# Altium schematic sheet VoltageSensorBoard.SchDoc(VoltageSensorBoard) (continued)

## Library data of the parts
B1 = CON_BARRIER_STRIP: Manufacturer: Molex; Wire Gauge (Min): 14AWG; Wire Gauge (Max): 22AWG; Voltage Rating: 300V; Pitch: 0.325inch; Number of Wire Entries: 2; Current Rating: 20A; Termination: Solder; Supplier Part Number 1: WM13920-ND; Supplier 1: Digi-Key; Stock 1: 2658; RoHS: Yes; Pricing 1: 1=2.41000, 10=1.82000, 25=1.68720, 50=1.51700, 100=1.48000, 250=1.29500, 500=1.18400, 1000=1.07300, 5000=0.94350 (USD); Packaging: Bulk; Package Quantity: 468; Orientation: Straight; Number of Rows: 1; Number of Contacts: 2; Number of Circuits: 2; Mount: Through Hole; Min Operating Temperature: -40°C; Max Supply Voltage: 300V; Max Operating Temperature: 130°C; Library Name: SensorCard.SchLib; Length: 18.5mm; Insulation Material: Thermoplastic; Housing Material: Thermoplastic; Housing Color: Black; Depth: 12.7mm; Contact Plating: Zinc; Contact Material: Steel; ComponentLink1URL: https://datasheet.ciiva.com/6772/387007501-sd-6772068.pdf?src-supplier=Digi-Key; ComponentLink1Description: https://datasheet.ciiva.com/6772/387007501-sd-6772068.pdf?src-supplier=Digi-Key; Component Kind: Standard; Color: Black
BNC1 = BNC: Manufacturer Part Number: 5227699-1; Manufacturer: TE Connectivity; Voltage Rating: 500V; Sealable: No (Without); Polarity: Standard; PCB Mount Retention: Without; PCB Mounting Orientation: Vertical; Number of Positions: 1; Max Frequency: 4GHz; Insulation Resistance: 5GR; Impedance: 50R; Gender: Female; Body Plating: Nickel; Body Material: Zinc; Termination: Solder; Supplier Part Number 1: A33798-ND; Supplier 1: Digi-Key; Stock 1: 1551; RoHS: Yes; REACH SVHC: Unknown; Radiation Hardening: No; Pricing 1: 1=3.67000, 10=3.52000, 30=3.22700, 60=3.08017, 120=2.93358, 270=2.56685, 510=2.49353, 1020=2.12683, 2520=1.98015 (USD); Packaging: Rail/Tube; Package Quantity: 30; Orientation: Straight; Mount: Through Hole; Min Operating Temperature: -55°C; Max Operating Temperature: 85°C; Library Name: SensorCard.SchLib; Length: 17.45mm; Lead Free: Lead Free; Frequency: 4GHz; Fastening Type: Bayonet; ELV: Compliant; Digikey Part no.: A33798-ND; Depth: 17.45mm; Contact Plating: Silver; Contact Material: Bronze; Connector Type: BNC; ComponentLink5URL: https://datasheet.ciiva.com/3131/eng-cs-1307191-bnc-connectors-0307-3131320.pdf?src-supplier=Avnet; ComponentLink5Description: https://datasheet.ciiva.com/3131/eng-cs-1307191-bnc-connectors-0307-3131320.pdf?src-supplier=Avnet; ComponentLink4URL: https://datasheet.ciiva.com/14565/70042557-14565526.pdf?src-supplier=Allied+Electronics; ComponentLink4Description: https://datasheet.ciiva.com/14565/70042557-14565526.pdf?src-supplier=Allied+Electronics; ComponentLink3URL: https://datasheet.ciiva.com/8044/1649653-8044086.pdf?src-supplier=Element14; ComponentLink3Description: https://datasheet.ciiva.com/8044/1649653-8044086.pdf?src-supplier=Element14; ComponentLink2URL: https://datasheet.ciiva.com/642/eng-cd-5227699-a1-642820.pdf?src-supplier=Digi-Key; ComponentLink2Description: https://datasheet.ciiva.com/642/eng-cd-5227699-a1-642820.pdf?src-supplier=Digi-Key; ComponentLink1URL: https://datasheet.ciiva.com/30491/0900766b8121e450-30491606.pdf?src-supplier=RSComponents; ComponentLink1Description: https://datasheet.ciiva.com/30491/0900766b8121e450-30491606.pdf?src-supplier=RSComponents; Component Kind: Standard
C1-C4 C9 = CMP-2006-03069-1: Manufacturer: Kemet; Voltage Rating (DC): 25V; Tolerance: 80%; Dissipation Factor: 7%; Dielectric Material: Ceramic; Dielectric: Y5V; Width: 0.031inch; Voltage Rating: 25V; Voltage: 25V; Thickness: 0.034inch; Termination: SMD/SMT; Supplier Part Number 1: 399-1100-1-ND; Supplier 1: Digi-Key; Stock 1: 1688362; RoHS: Yes; REACH SVHC: No SVHC; Radiation Hardening: No; Pricing 1: 1=0.10000, 10=0.03500, 100=0.01560, 500=0.01114, 1000=0.00875 (USD); Part Number: C0603C104Z3VACTU; Packaging: Tape and Reel; Package Quantity: 1; Number of Pins: 2; Mount: Surface Mount; Min Operating Temperature: -30°C; Max Operating Temperature: 85°C; Manufacturer Part Number: C0603C104Z3VACTU; Library Name: Altium Content Vault; Length: 1.6mm; Lead Pitch: 0.7mm; Lead Free: Lead Free; Height: 0.8mm; Depth: 0.8mm; ComponentLink4URL: https://datasheet.ciiva.com/14589/70095383-14589007.pdf?src-supplier=Allied+Electronics; ComponentLink4Description: https://datasheet.ciiva.com/14589/70095383-14589007.pdf?src-supplier=Allied+Electronics; ComponentLink3URL: https://datasheet.ciiva.com/46443/1828802-46443709.pdf?src-supplier=Element14; ComponentLink3Description: https://datasheet.ciiva.com/46443/1828802-46443709.pdf?src-supplier=Element14; ComponentLink2URL: https://datasheet.ciiva.com/46443/1828802-46443708.pdf?src-supplier=Element14; ComponentLink2Description: https://datasheet.ciiva.com/46443/1828802-46443708.pdf?src-supplier=Element14; ComponentLink1URL: https://datasheet.ciiva.com/7823/1470417-7823994.pdf?src-supplier=Element14; ComponentLink1Description: https://datasheet.ciiva.com/7823/1470417-7823994.pdf?src-supplier=Element14; Component Kind: Standard; Case Code (Metric): 1608; Case Code (Imperial): 0603; Case/Package: 0603
C5 = CMP-1662-00022-1: Chip Capacitor, 1 nF, +/- 5%, 50 V, -55 to 125 degC, 0603 (1608 Metric), RoHS, Tape and Reel; Manufacturer: Murata; Voltage Rating (DC): 50 V; Voltage: 50V; Temperature Coefficient: 30ppm/°C; Resistance: 100000000000 Ohm; Material: Ceramic; Insulation Resistance: 10GR; Width: 0.8 mm; Voltage Rating: 50 V; Tolerance: 5%; Thickness: 0.035inch; Termination: SMD/SMT; Supplier Part Number 1: 490-1451-1-ND; Supplier 1: Digi-Key; Stock 1: 1837786; RoHSCompliant: True; RoHS: Yes; REACH SVHC: No SVHC; Radiation Hardening: No; Pricing 1: 1=0.10000, 10=0.07000, 100=0.03300, 500=0.02314, 1000=0.01916 (USD); Pins: 2; Packaging: Tape and Reel; PackageReference: 0603; Package Quantity: 4000; PackageDescription: 2-Pin Surface Mount Device, Body 1.6 x 0.8 mm; Number of Pins: 2; Mount: Surface Mount; Min Operating Temperature: -55degC; Max Operating Temperature: 125degC; Manufacturer Part Number: GRM1885C1H102JA01D; Library Name: Altium Content Vault; Length: 1.6 mm; Lead Free: Lead Free; Height: 0.8 mm; Dielectric Material: Ceramic; Dielectric: C0G; Depth: 0.8mm; DatasheetVersion: Mar-16; ComponentLink12URL: https://datasheet.ciiva.com/7828/4360-7828895.pdf?src-supplier=Element14; ComponentLink12Description: https://datasheet.ciiva.com/7828/4360-7828895.pdf?src-supplier=Element14; ComponentLink11URL: https://datasheet.ciiva.com/7828/1784402-7828894.pdf?src-supplier=Element14; ComponentLink11Description: https://datasheet.ciiva.com/7828/1784402-7828894.pdf?src-supplier=Element14; ComponentLink10URL: https://datasheet.ciiva.com/7828/1784402-7828893.pdf?src-supplier=Element14; ComponentLink10Description: https://datasheet.ciiva.com/7828/1784402-7828893.pdf?src-supplier=Element14; ComponentLink9URL: https://datasheet.ciiva.com/131/partnumbering-e-01-131965.pdf?src-supplier=Digi-Key; ComponentLink9Description: https://datasheet.ciiva.com/131/partnumbering-e-01-131965.pdf?src-supplier=Digi-Key; ComponentLink8URL: https://datasheet.ciiva.com/6072/4360-6072460.pdf?src-supplier=Farnell; ComponentLink8Description: https://datasheet.ciiva.com/6072/4360-6072460.pdf?src-supplier=Farnell; ComponentLink7URL: https://datasheet.ciiva.com/131/partnumbering-e-01-131964.pdf?src-supplier=Digi-Key; ComponentLink7Description: https://datasheet.ciiva.com/131/partnumbering-e-01-131964.pdf?src-supplier=Digi-Key; ComponentLink6URL: https://datasheet.ciiva.com/131/partnumbering-e-01-131963.pdf?src-supplier=Digi-Key; ComponentLink6Description: https://datasheet.ciiva.com/131/partnumbering-e-01-131963.pdf?src-supplier=Digi-Key; ComponentLink5URL: https://datasheet.ciiva.com/5421/product-5421450.pdf?src-supplier=Mouser; ComponentLink5Description: https://datasheet.ciiva.com/5421/product-5421450.pdf?src-supplier=Mouser; ComponentLink4URL: https://datasheet.ciiva.com/29149/450301-da-01-en-vs-kondensator-0603-29149020.pdf?src-supplier=Conrad; ComponentLink4Description: https://datasheet.ciiva.com/29149/450301-da-01-en-vs-kondensator-0603-29149020.pdf?src-supplier=Conrad; ComponentLink3URL: https://datasheet.ciiva.com/18184/getdatasheetpartid-153109-18184812.pdf?src-supplier=Verical; ComponentLink3Description: https://datasheet.ciiva.com/18184/getdatasheetpartid-153109-18184812.pdf?src-supplier=Verical; ComponentLink2URL: http://search.murata.co.jp/Ceramy/image/img/A01X/G101/ENG/GRM1885C1H102JA01-01.pdf; ComponentLink2Description: Datasheet; ComponentLink1URL: http://www.murata.com/; ComponentLink1Description: Manufacturer URL; Component Kind: Standard; Case Code (Metric): 1608; Case Code (Imperial): 0603; Case/Package: 0603
C6-C8 C10 = Cap: Capacitor; Manufacturer Part Number: CL21A106KAFN3NE; Manufacturer: Samsung; Voltage Rating: 25V; Tolerance: 10%; Width: 0.049inch; Thickness: 0.053inch; Supplier Part Number 1: 1276-2890-1-ND; Supplier 1: Digi-Key; Stock 1: 51388; RoHS: Yes; Pricing 1: 1=0.28000, 10=0.19300, 100=0.09770, 500=0.06684, 1000=0.05912 (USD); Packaging: Cut Tape; Package Quantity: 1; Mount: Surface Mount; Min Operating Temperature: -55°C; Max Operating Temperature: 85°C; Library Name: SensorCard.SchLib; Length: 0.079inch; Lead Free: Lead Free; ComponentLink1URL: https://datasheet.ciiva.com/25720/cl21a106kafn3ne-25720275.pdf?src-supplier=Digi-Key; ComponentLink1Description: https://datasheet.ciiva.com/25720/cl21a106kafn3ne-25720275.pdf?src-supplier=Digi-Key; Component Kind: Standard; Case Code (Metric): 2012; Case Code (Imperial): 0805; Case/Package: 0805
DS1 = CMP-2000-06622-1: LED Green, 75 mW, 80 mA, -55 to 85 degC, 2-Pin SMD, RoHS, Tape and Reel; Manufacturer: Lite-On; Viewing Angle: 130°; Test Current: 5mA; Reverse Voltage: 5V; Power Rating: 75mW; Number of LEDs: 1; Luminous Intensity: 28mcd; Lens Type: Clear; Lens Style: Diffused; Lens Color: Colorless; Forward Voltage: 2.3V; Forward Current: 30mA; Dominant Wavelength: 576.5nm; Width: 0.8mm; Supplier Part Number 1: 160-1828-1-ND; Supplier 1: Digi-Key; Stock 1: 98599; RoHS: Yes; Radiation Hardening: No; Pricing 1: 1=0.41000, 10=0.29600, 25=0.22680, 100=0.14800, 250=0.10852, 500=0.09210, 1000=0.07565, 2500=0.06578 (USD); Power Dissipation: 75mW; Packaging: Tape and Reel; Package Reference: C193KGKT-5A; Package Quantity: 3000; Package Description: 2-Pin Surface Mount Device, Body 1.6 x 0.8 mm; Number of Pins: 2; Number of Elements: 1; Mounting Technology: SurfaceMount; Mount: Surface Mount; Min Operating Temperature: -55°C; Max Operating Temperature: 85°C; Manufacturer URL: http://www.us.liteon.com/; Manufacturer Part Number: LTST-C193KGKT-5A; Library Name: Altium Content Vault; Lens Transparency: Clear; Length: 1.6mm; Lead Free: Lead Free; Intensity: 28mcd; Illumination Color: Green; Height: 0.35mm; Forward (Drive) Current: 5mA; Dimension: 1.6x0.8x0.35mm; Depth: 0.8mm; Datasheet Version: 03/2007; Datasheet URL: http://optoelectronics.liteon.com/upload/download/DS22-2007-0094/S_110_LTST-C193KGKT-5A.pdf; ComponentLink6URL: https://datasheet.ciiva.com/2110/s-110-ltst-c193kgkt-5a-2110268.pdf?src-supplier=Digi-Key; ComponentLink6Description: https://datasheet.ciiva.com/2110/s-110-ltst-c193kgkt-5a-2110268.pdf?src-supplier=Digi-Key; ComponentLink5URL: https://datasheet.ciiva.com/2110/s-110-ltst-c193kgkt-5a-2110269.pdf?src-supplier=Digi-Key; ComponentLink5Description: https://datasheet.ciiva.com/2110/s-110-ltst-c193kgkt-5a-2110269.pdf?src-supplier=Digi-Key; ComponentLink4URL: https://datasheet.ciiva.com/2110/s-110-ltst-c193kgkt-5a-2110267.pdf?src-supplier=Digi-Key; ComponentLink4Description: https://datasheet.ciiva.com/2110/s-110-ltst-c193kgkt-5a-2110267.pdf?src-supplier=Digi-Key; ComponentLink3URL: https://datasheet.ciiva.com/16444/ltst-c193kgkt-5a-16444759.pdf?src-supplier=Future+Electronics; ComponentLink3Description: https://datasheet.ciiva.com/16444/ltst-c193kgkt-5a-16444759.pdf?src-supplier=Future+Electronics; ComponentLink2URL: https://datasheet.ciiva.com/11773/0900766b80dc0550-11773612.pdf?src-supplier=RSComponents; ComponentLink2Description: https://datasheet.ciiva.com/11773/0900766b80dc0550-11773612.pdf?src-supplier=RSComponents; ComponentLink1URL: https://datasheet.ciiva.com/12400/ltst-c193-series-201756-12400795.pdf?src-supplier=Mouser; ComponentLink1Description: https://datasheet.ciiva.com/12400/ltst-c193-series-201756-12400795.pdf?src-supplier=Mouser; Component Kind: Standard; Color: Green; Case Code (Metric): 1608; Case Code (Imperial): 0603; Case/Package: 0603
DS2 = CMP-2000-06624-1: LED Uni-Color Blue, 76 mW, 20 mA, -20 to 80 degC, 2-Pin SMD, RoHS, Tape and Reel; Manufacturer: Lite-On; Wavelength/Color Temperature: 465nmto475nm; Viewing Angle: 130deg; Test Current: 5mA; Reverse Voltage: 5V; Power Dissipation: 76mW; Number of LEDs: 1; Luminous Intensity: 15mcd; Lens Transparency: Clear; Lens Style: Diffused; Lens Color: Colorless; LED Size: 1.6mmx0.8mmx0.35mm; Forward Voltage: 2.8V; Dominant Wavelength: 475nm; Width: 0.8mm; Supplier Part Number 1: 160-1827-1-ND; Supplier 1: Digi-Key; Stock 1: 234360; RoHS: Details; Radiation Hardening: No; Product Category: StandardLEDs-SMD; Pricing 1: 1=0.47000, 10=0.33600, 25=0.25720, 100=0.16780, 250=0.12304, 500=0.10442, 1000=0.08577, 2500=0.07458 (USD); Packaging: Reel; Package Reference: C193TBKT; Package Quantity: 1; Package Description: 2-Pin Surface Mount Device, Body 0.8 x 1.6 mm; Package / Case: 0603; Number of Pins: 2; Number of Elements: 1; Mounting Technology: SurfaceMount; Mount: Surface Mount; Min Operating Temperature: -20°C; Minimum Operating Temperature: -20C; Max Operating Temperature: 80°C; Maximum Operating Temperature: +80C; Manufacturer URL: http://www.us.liteon.com/; Manufacturer Part Number: LTST-C193TBKT-5A; Library Name: Altium Content Vault; Length: 1.6mm; Lead Free: Lead Free; Illumination Color: Blue; Height: 0.35mm; Forward Current: 5mA; Factory Pack Quantity: 3000; Depth: 0.8mm; Datasheet Version: 08/2011; Datasheet URL: https://datasheet.ciiva.com/15201/getdatasheetpartid-293056-15201395.pdf; ComponentLink7URL: https://datasheet.ciiva.com/2111/p-100-ltst-c193tbkt-5a-2111221.pdf?src-supplier=Digi-Key; ComponentLink7Description: https://datasheet.ciiva.com/2111/p-100-ltst-c193tbkt-5a-2111221.pdf?src-supplier=Digi-Key; ComponentLink6URL: https://datasheet.ciiva.com/2111/p-100-ltst-c193tbkt-5a-2111220.pdf?src-supplier=Digi-Key; ComponentLink6Description: https://datasheet.ciiva.com/2111/p-100-ltst-c193tbkt-5a-2111220.pdf?src-supplier=Digi-Key; ComponentLink5URL: https://datasheet.ciiva.com/16210/ltst-c193tbkt-5a-16210824.pdf?src-supplier=Future+Electronics; ComponentLink5Description: https://datasheet.ciiva.com/16210/ltst-c193tbkt-5a-16210824.pdf?src-supplier=Future+Electronics; ComponentLink4URL: https://datasheet.ciiva.com/11773/0900766b80dc0554-11773880.pdf?src-supplier=RSComponents; ComponentLink4Description: https://datasheet.ciiva.com/11773/0900766b80dc0554-11773880.pdf?src-supplier=RSComponents; ComponentLink3URL: https://datasheet.ciiva.com/15201/getdatasheetpartid-293056-15201395.pdf?src-supplier=Verical; ComponentLink3Description: https://datasheet.ciiva.com/15201/getdatasheetpartid-293056-15201395.pdf?src-supplier=Verical; ComponentLink2URL: https://datasheet.ciiva.com/2111/ltst-c193tbkt-5a-2111219.pdf?src-supplier=Digi-Key; ComponentLink2Description: https://datasheet.ciiva.com/2111/ltst-c193tbkt-5a-2111219.pdf?src-supplier=Digi-Key; ComponentLink1URL: https://datasheet.ciiva.com/4688/lite-on-ltst-c193tbkt-5a-187386-4688832.pdf?src-supplier=Mouser; ComponentLink1Description: https://datasheet.ciiva.com/4688/lite-on-ltst-c193tbkt-5a-187386-4688832.pdf?src-supplier=Mouser; Component Kind: Standard; Color: Blue; Case Code (Metric): 1608; Case Code (Imperial): 0603; Case/Package: 0603; Brand: Lite-On
IC1 = LV25-P: LA 25-P Voltage Sensor; Library Name: SensorCard.SchLib; Digikey Part no.: 398-1010-ND; Component Kind: Standard
L1-L3 = CMP-0220-00193-1: SMD EMI Suppression Ferrite Bead WE-CBF, Z = 30 Ohm; Manufacturer: Wurth Electronics; Tolerance: 25%; Test Frequency: 100MHz; Resistance: 40mR; Number of Lines: 1; Max DC Current: 3A; Inductance: 5.6H; Impedance: 30 Ohm; Current Rating: 1A; Width: 0.8mm; Weight: 0.000141oz; Termination: SMD/SMT; Supplier Part Number 1: 732-1591-1-ND; Supplier 1: Digi-Key; Stock 1: 70099; RoHS: Yes; REACH SVHC: Unknown; Pricing 1: 1=0.17000, 50=0.16800, 100=0.15500, 500=0.14800, 1000=0.14100, 2000=0.13400 (USD); Packaging: Tape and Reel; PackageVersion: 2009; PackageReference: SMD-0603; Package Quantity: 1; PackageDescription: Chip Inductor, 2-Leads, Body 1.6 x 0.8 mm; Mount: Surface Mount; Min Operating Temperature: -55°C; Max Operating Temperature: 125°C; Max Current Rating: 3A; Material: Ferrite; Manufacturer Part Number: 742792609; Library Name: Altium Content Vault; Length: 1.6mm; Height: 0.8mm; Depth: 0.8mm; DC Resistance (DCR): 40mR; DatasheetVersion: Dec-2005; Core Material: Ferrite; ComponentLink11URL: https://datasheet.ciiva.com/1013/742792609-1013544.pdf?src-supplier=Digi-Key; ComponentLink11Description: https://datasheet.ciiva.com/1013/742792609-1013544.pdf?src-supplier=Digi-Key; ComponentLink10URL: https://datasheet.ciiva.com/1013/742792609-1013543.pdf?src-supplier=Digi-Key; ComponentLink10Description: https://datasheet.ciiva.com/1013/742792609-1013543.pdf?src-supplier=Digi-Key; ComponentLink9URL: https://datasheet.ciiva.com/1466/1696069-1466420.pdf?src-supplier=Element14; ComponentLink9Description: https://datasheet.ciiva.com/1466/1696069-1466420.pdf?src-supplier=Element14; ComponentLink8URL: https://datasheet.ciiva.com/23762/742792609-23762991.pdf?src-supplier=Newark; ComponentLink8Description: https://datasheet.ciiva.com/23762/742792609-23762991.pdf?src-supplier=Newark; ComponentLink7URL: https://datasheet.ciiva.com/11799/0900766b80d2ddc1-11799675.pdf?src-supplier=RSComponents; ComponentLink7Description: https://datasheet.ciiva.com/11799/0900766b80d2ddc1-11799675.pdf?src-supplier=RSComponents; ComponentLink6URL: https://datasheet.ciiva.com/14634/0900766b80d2ddc1-14634954.pdf?src-supplier=Allied+Electronics; ComponentLink6Description: https://datasheet.ciiva.com/14634/0900766b80d2ddc1-14634954.pdf?src-supplier=Allied+Electronics; ComponentLink5URL: https://datasheet.ciiva.com/4940/742792609-79267-4940428.pdf?src-supplier=Mouser; ComponentLink5Description: https://datasheet.ciiva.com/4940/742792609-79267-4940428.pdf?src-supplier=Mouser; ComponentLink4URL: https://datasheet.ciiva.com/1013/742792609-1013542.pdf?src-supplier=Digi-Key; ComponentLink4Description: https://datasheet.ciiva.com/1013/742792609-1013542.pdf?src-supplier=Digi-Key; ComponentLink3URL: https://datasheet.ciiva.com/22711/1696069-22711408.pdf?src-supplier=Element14; ComponentLink3Description: https://datasheet.ciiva.com/22711/1696069-22711408.pdf?src-supplier=Element14; ComponentLink2URL: http://www.we-online.de/katalog/media/pdf/742792609.pdf; ComponentLink2Description: Datasheet; ComponentLink1URL: http://www.we-online.com; ComponentLink1Description: Manufacturer Link; Component Kind: Standard; Case Code (Metric): 1608; Case Code (Imperial): 0603; Case/Package: SMD/SMT
MH1 MH2 = MOUNT_HOLE: MOUNTING HOLE; Library Name: SensorCard.SchLib; Component Kind: Standard
P1 = HDR1x3: Manufacturer Part Number: 282834-3; Manufacturer: TE Connectivity; Wire Gauge (Min): 30AWG; Voltage Rating: 150V; Thread Size: M1.6; Tail Length: 3.4mm; Side Stackable: Yes (With); Screw Flange: No (Without); Plating: Brass; Pitch: 2.54mm; Number of Positions Per Level: 3; Number of Levels: 1; Max Current Rating: 10A; Connector Type: Receptacle; Approvals: UL; Wire Gauge (Max): 30AWG; Wire/Cable Gauge: 30AWG; Width: 10mm; Voltage: 150V; Termination: Solder; Supplier Part Number 1: A98334-ND; Supplier 1: Digi-Key; Stock 1: 4635; Screwless Terminal Block: Yes (With); RoHS: Yes; REACH SVHC: Unknown; Radiation Hardening: No; Pricing 1: 1=2.01000, 10=1.52300, 25=1.41160, 50=1.26920, 100=1.23820, 250=1.08340, 500=0.99052, 1000=0.89767, 5000=0.78933 (USD); Packaging: Bulk; Package Quantity: 200; Orientation: Straight; Number of Rows: 1; Number of Positions: 3; Number of Poles: 3; Number of Contacts: 3; Number of Circuits: 3; Mount: Through Hole; Min Operating Temperature: -40°C; Max Voltage Rating (AC): 150V; Max Supply Voltage (AC): 150V; Max Operating Temperature: 105°C; Library Name: SensorCard.SchLib; Length: 8.08mm; Lead Free: Lead Free; Interlock: No (Without); Housing Material: Polyamide; Housing Color: Green; Flammability Rating: UL94 V-0; ELV: Compliant; Depth: 6.5mm; Current Rating: 10A; Current: 10A; Contact Plating: Tin; Contact Material: Brass; ComponentLink8URL: https://datasheet.ciiva.com/7978/583107-7978559.pdf?src-supplier=Element14; ComponentLink8Description: https://datasheet.ciiva.com/7978/583107-7978559.pdf?src-supplier=Element14; ComponentLink7URL: https://datasheet.ciiva.com/16469/eng-cs-1308389-eurostyle-terminal-blocks-0607-16469502.pdf?src-supplier=Avnet+Asia; ComponentLink7Description: https://datasheet.ciiva.com/16469/eng-cs-1308389-eurostyle-terminal-blocks-0607-16469502.pdf?src-supplier=Avnet+Asia; ComponentLink6URL: https://datasheet.ciiva.com/3141/eng-cs-1308389-eurostyle-terminal-blocks-0607-3141116.pdf?src-supplier=Avnet; ComponentLink6Description: https://datasheet.ciiva.com/3141/eng-cs-1308389-eurostyle-terminal-blocks-0607-3141116.pdf?src-supplier=Avnet; ComponentLink5URL: https://datasheet.ciiva.com/14610/70087777-14610266.pdf?src-supplier=Allied+Electronics; ComponentLink5Description: https://datasheet.ciiva.com/14610/70087777-14610266.pdf?src-supplier=Allied+Electronics; ComponentLink4URL: https://datasheet.ciiva.com/823/eng-cd-282834-c1-823464.pdf?src-supplier=Digi-Key; ComponentLink4Description: https://datasheet.ciiva.com/823/eng-cd-282834-c1-823464.pdf?src-supplier=Digi-Key; ComponentLink3URL: https://datasheet.ciiva.com/30217/eng-cs-1308389-eurostyle-terminal-blocks-0607-30217495.pdf?src-supplier=Onlinecomponents.com; ComponentLink3Description: https://datasheet.ciiva.com/30217/eng-cs-1308389-eurostyle-terminal-blocks-0607-30217495.pdf?src-supplier=Onlinecomponents.com; ComponentLink2URL: https://datasheet.ciiva.com/15169/getdatasheetpartid-155865-15169559.pdf?src-supplier=Verical; ComponentLink2Description: https://datasheet.ciiva.com/15169/getdatasheetpartid-155865-15169559.pdf?src-supplier=Verical; ComponentLink1URL: https://datasheet.ciiva.com/11757/0900766b80f924bc-11757569.pdf?src-supplier=RSComponents; ComponentLink1Description: https://datasheet.ciiva.com/11757/0900766b80f924bc-11757569.pdf?src-supplier=RSComponents; Component Kind: Standard; Color: Green; Closed End: No (Without)
P2 = HDR1X6: Manufacturer Part Number: 215299-6; Manufacturer: TE Connectivity; Tail Length: 3.1mm; Stackable: Yes; Sealable: No (Without); Plating Thickness: 4um; Pitch: 2.54mm; PCB Thickness: 1.6mm; PCB Mount Retention: With; PCB Mounting Orientation: Vertical; Number of Positions: 6; Current Rating: 3A; Contact Resistance: 12mR; Connector Type: Receptacle; Termination: Solder; Supplier Part Number 1: A121761-ND; Supplier 1: Digi-Key; Stock 1: 1409; RoHS: Yes; Radiation Hardening: No; Pricing 1: 1=1.16000, 10=1.02000, 100=0.88000, 500=0.72000, 1000=0.64000, 5000=0.56000, 10000=0.53200 (USD); Packaging: Tray; Package Quantity: 120; Orientation: Straight; Number of Rows: 1; Number of Contacts: 6; Mount: Through Hole; Min Operating Temperature: -55°C; Max Operating Temperature: 105°C; Library Name: SensorCard.SchLib; Length: 15.24mm; Lead Free: Lead Free; Housing Material: Polyester; Housing Color: Black; Height: 8.5mm; Flammability Rating: UL94 V-0; ELV: Compliant; Depth: 2.52mm; Contact Shape: Square; Contact Post Length: 3.1mm; Contact Plating: Gold; Contact Material: Bronze; Contact Current Rating: 3A; ComponentLink2URL: https://datasheet.ciiva.com/43513/2152996-43513418.pdf?src-supplier=Onlinecomponents.com; ComponentLink2Description: https://datasheet.ciiva.com/43513/2152996-43513418.pdf?src-supplier=Onlinecomponents.com; ComponentLink1URL: https://datasheet.ciiva.com/29369/001094900-da-01-en-buchsenleiste-1-reihig-0-0215299-6-29369971.pdf?src-supplier=Conrad; ComponentLink1Description: https://datasheet.ciiva.com/29369/001094900-da-01-en-buchsenleiste-1-reihig-0-0215299-6-29369971.pdf?src-supplier=Conrad; Component Kind: Standard; Color: Green; Boss: No (Without)
P3 = HDR1x3: Manufacturer Part Number: 215297-3; Manufacturer: TE Connectivity; Tail Length: 3.1mm; Stackable: Yes; Sealable: No (Without); Pitch: 2.54mm; PCB Thickness: 1.6mm; PCB Mount Retention: With; PCB Mounting Orientation: Vertical; Number of Positions: 3; Gender: Receptacle; Current Rating: 3A; Contact Resistance: 12mR; Termination: Solder; Supplier Part Number 1: A106650-ND; Supplier 1: Digi-Key; Stock 1: 7420; RoHS: Yes; Pricing 1: 1=0.81000, 10=0.71400, 100=0.61600, 500=0.50400, 1000=0.44800, 5000=0.39200, 10000=0.37240 (USD); Packaging: Tray; Package Quantity: 240; Orientation: Straight; Number of Rows: 1; Number of Contacts: 3; Mount: Through Hole; Min Operating Temperature: -55°C; Max Operating Temperature: 105°C; Library Name: SensorCard.SchLib; Length: 7.62mm; Housing Material: Polyester; Housing Color: Black; Height: 7mm; Flammability Rating: UL94 V-0; ELV: Compliant; Depth: 2.52mm; Contact Shape: Square; Contact Post Length: 3.1mm; Contact Plating: Gold; Contact Material: Bronze; Contact Gender: Female; Contact Current Rating: 3A; Connector Type: Receptacle; ComponentLink6URL: https://datasheet.ciiva.com/14120/215297serie-eng-tds-14120829.pdf?src-supplier=Distrelec; ComponentLink6Description: https://datasheet.ciiva.com/14120/215297serie-eng-tds-14120829.pdf?src-supplier=Distrelec; ComponentLink5URL: https://datasheet.ciiva.com/1439/1651969-1439469.pdf?src-supplier=Farnell; ComponentLink5Description: https://datasheet.ciiva.com/1439/1651969-1439469.pdf?src-supplier=Farnell; ComponentLink4URL: https://datasheet.ciiva.com/6857/eng-cd-215297-d-basefilename-6857450.pdf?src-supplier=Digi-Key; ComponentLink4Description: https://datasheet.ciiva.com/6857/eng-cd-215297-d-basefilename-6857450.pdf?src-supplier=Digi-Key; ComponentLink3URL: https://datasheet.ciiva.com/8003/525120-8003961.pdf?src-supplier=Element14; ComponentLink3Description: https://datasheet.ciiva.com/8003/525120-8003961.pdf?src-supplier=Element14; ComponentLink2URL: https://datasheet.ciiva.com/11748/0900766b805762f6-11748764.pdf?src-supplier=RSComponents; ComponentLink2Description: https://datasheet.ciiva.com/11748/0900766b805762f6-11748764.pdf?src-supplier=RSComponents; ComponentLink1URL: https://datasheet.ciiva.com/32373/2152973-32373113.pdf?src-supplier=Onlinecomponents.com; ComponentLink1Description: https://datasheet.ciiva.com/32373/2152973-32373113.pdf?src-supplier=Onlinecomponents.com; Component Kind: Standard; Color: Green
R1 = CMP-2000-00767-1: Manufacturer Part Number: ERJ3GEYJ302V; Manufacturer: Panasonic; Voltage Rating: 75V; Tolerance: 5%; Temperature Coefficient: 200ppm/°C; Power Rating: 100mW; Width: 0.031inch; Termination: SMD/SMT; Supplier Part Number 1: P3.0KGCT-ND; Supplier 1: Digi-Key; Stock 1: 269975; RoHS: Yes; REACH SVHC: No SVHC; Pricing 1: 1=0.10000, 10=0.04300, 100=0.01760, 1000=0.00791, 2500=0.00686 (USD); Part Number: ERJ-3GEYJ302V; Packaging: Tape and Reel; Package Quantity: 1; Number of Terminations: 2; Mount: Surface Mount; Min Operating Temperature: -55°C; Military Standard: Not; Max Power Dissipation: 100mW; Max Operating Temperature: 155°C; Library Name: Altium Content Vault; Length: 1.6mm; Height: 0.45mm; Features: Automotive AEC-Q200; Depth: 0.8mm; Contact Plating: Tin; Composition: Thick Film; ComponentLink10URL: https://datasheet.ciiva.com/28691/1678796-28691966.pdf?src-supplier=Element14; ComponentLink10Description: https://datasheet.ciiva.com/28691/1678796-28691966.pdf?src-supplier=Element14; ComponentLink9URL: https://datasheet.ciiva.com/28565/1678796-28565150.pdf?src-supplier=Element14; ComponentLink9Description: https://datasheet.ciiva.com/28565/1678796-28565150.pdf?src-supplier=Element14; ComponentLink8URL: https://datasheet.ciiva.com/30196/4aoa0000ce1-30196300.pdf?src-supplier=Onlinecomponents.com; ComponentLink8Description: https://datasheet.ciiva.com/30196/4aoa0000ce1-30196300.pdf?src-supplier=Onlinecomponents.com; ComponentLink7URL: https://datasheet.ciiva.com/46386/1500035-46386731.pdf?src-supplier=Element14; ComponentLink7Description: https://datasheet.ciiva.com/46386/1500035-46386731.pdf?src-supplier=Element14; ComponentLink6URL: https://datasheet.ciiva.com/9196/aoa0000ce1-9196746.pdf?src-supplier=Avnet; ComponentLink6Description: https://datasheet.ciiva.com/9196/aoa0000ce1-9196746.pdf?src-supplier=Avnet; ComponentLink5URL: https://datasheet.ciiva.com/16786/70255313-16786823.pdf?src-supplier=Allied+Electronics; ComponentLink5Description: https://datasheet.ciiva.com/16786/70255313-16786823.pdf?src-supplier=Allied+Electronics; ComponentLink4URL: https://datasheet.ciiva.com/9196/aoa0000ce1-9196743.pdf?src-supplier=Avnet; ComponentLink4Description: https://datasheet.ciiva.com/9196/aoa0000ce1-9196743.pdf?src-supplier=Avnet; ComponentLink3URL: https://datasheet.ciiva.com/43460/1500035-43460725.pdf?src-supplier=Farnell; ComponentLink3Description: https://datasheet.ciiva.com/43460/1500035-43460725.pdf?src-supplier=Farnell; ComponentLink2URL: https://datasheet.ciiva.com/24027/aoa0000ce1-37422-24027397.pdf?src-supplier=Mouser; ComponentLink2Description: https://datasheet.ciiva.com/24027/aoa0000ce1-37422-24027397.pdf?src-supplier=Mouser; ComponentLink1URL: https://datasheet.ciiva.com/11821/0900766b80f806dd-11821475.pdf?src-supplier=RSComponents; ComponentLink1Description: https://datasheet.ciiva.com/11821/0900766b80f806dd-11821475.pdf?src-supplier=RSComponents; Component Kind: Standard; Case Code (Metric): 1608; Case Code (Imperial): 0603; Case/Package: 0603
R2 = CMP-1659-00035-1: General Purpose Chip Resistor, 470 Ohm, +/- 1%, -55 to 155 degC, 0603 (1608 Metric), RoHS, Tape and Reel; Manufacturer: Yageo; Working Voltage: 75V; Voltage Rating: 50V; Temperature Coefficient: 100ppm/°C; Resistance: 470; Power Rating: 100mW; Width: 0.031inch; Tolerance: 1%; Termination: SMD/SMT; Supplier Part Number 1: 311-470HRCT-ND; Supplier 1: Digi-Key; Stock 1: 7151461; RoHS: Yes; REACH SVHC: No SVHC; Pricing 1: 1=0.10000, 10=0.02400, 100=0.00970, 1000=0.00437, 2500=0.00379 (USD); Packaging: Tape and Reel; Package Quantity: 1; Number of Terminations: 2; Min Operating Temperature: -55°C; Military Standard: Not; Max Power Dissipation: 100mW; Max Operating Temperature: 155°C; Manufacturer Part Number: RC0603FR-07470RL; Library Name: Altium Content Vault; Length: 1.6mm; Lead Free: Lead Free; Height: 0.022inch; Features: Moisture Resistant; Depth: 0.8mm; Composition: Thick Film; ComponentLink10URL: https://datasheet.ciiva.com/2454/pyu-r-int-thick-7-2454014.pdf?src-supplier=Digi-Key; ComponentLink10Description: https://datasheet.ciiva.com/2454/pyu-r-int-thick-7-2454014.pdf?src-supplier=Digi-Key; ComponentLink9URL: https://datasheet.ciiva.com/2454/pyu-r-int-thick-7-2454013.pdf?src-supplier=Digi-Key; ComponentLink9Description: https://datasheet.ciiva.com/2454/pyu-r-int-thick-7-2454013.pdf?src-supplier=Digi-Key; ComponentLink8URL: https://datasheet.ciiva.com/2454/pyu-r-int-thick-7-2454012.pdf?src-supplier=Digi-Key; ComponentLink8Description: https://datasheet.ciiva.com/2454/pyu-r-int-thick-7-2454012.pdf?src-supplier=Digi-Key; ComponentLink7URL: https://datasheet.ciiva.com/46440/1868787-46440907.pdf?src-supplier=Element14; ComponentLink7Description: https://datasheet.ciiva.com/46440/1868787-46440907.pdf?src-supplier=Element14; ComponentLink6URL: https://datasheet.ciiva.com/7660/1868787-7660793.pdf?src-supplier=Element14; ComponentLink6Description: https://datasheet.ciiva.com/7660/1868787-7660793.pdf?src-supplier=Element14; ComponentLink5URL: https://datasheet.ciiva.com/7746/1738695-7746360.pdf?src-supplier=Element14; ComponentLink5Description: https://datasheet.ciiva.com/7746/1738695-7746360.pdf?src-supplier=Element14; ComponentLink4URL: https://datasheet.ciiva.com/7746/1738695-7746359.pdf?src-supplier=Element14; ComponentLink4Description: https://datasheet.ciiva.com/7746/1738695-7746359.pdf?src-supplier=Element14; ComponentLink3URL: https://datasheet.ciiva.com/11808/0900766b80f96399-11808177.pdf?src-supplier=RSComponents; ComponentLink3Description: https://datasheet.ciiva.com/11808/0900766b80f96399-11808177.pdf?src-supplier=RSComponents; ComponentLink2URL: https://datasheet.ciiva.com/11808/0900766b80f96399-11808177.pdf; ComponentLink2Description: Datasheet; ComponentLink1URL: http://www.yageo.com/; ComponentLink1Description: Manufacturer URL; Component Kind: Standard; Case Code (Metric): 1608; Case Code (Imperial): 0603; Case/Package: 0603
R3 R7 = CMP-2000-00751-1: Manufacturer: Panasonic; Voltage Rating (DC): 50V; Voltage Rating: 75V; Tolerance: 5%; Temperature Coefficient: 200ppm/°C; Resistance: 24; Power Rating: 100mW; Width: 0.8mm; Termination: SMD/SMT; Supplier Part Number 1: P24GCT-ND; Supplier 1: Digi-Key; Stock 1: 46471; RoHS: Yes; REACH SVHC: No SVHC; Radiation Hardening: No; Pricing 1: 1=0.10000, 10=0.04300, 100=0.01760, 1000=0.00791, 2500=0.00686 (USD); Part Number: ERJ-3GEYJ240V; Packaging: Tape and Reel; Package Quantity: 1; Number of Terminations: 2; Mount: Surface Mount; Min Operating Temperature: -55°C; Military Standard: Not; Max Power Dissipation: 100mW; Max Operating Temperature: 155°C; Manufacturer Part Number: ERJ-3GEYJ240V; Library Name: Altium Content Vault; Length: 1.6mm; Lead Free: Lead Free; Height: 0.45mm; Features: Automotive AEC-Q200; Depth: 0.8mm; Contact Plating: Tin; Composition: Thick Film; ComponentLink7URL: https://datasheet.ciiva.com/16758/70255313-16758191.pdf?src-supplier=Allied+Electronics; ComponentLink7Description: https://datasheet.ciiva.com/16758/70255313-16758191.pdf?src-supplier=Allied+Electronics; ComponentLink6URL: https://datasheet.ciiva.com/28693/1678796-28693886.pdf?src-supplier=Element14; ComponentLink6Description: https://datasheet.ciiva.com/28693/1678796-28693886.pdf?src-supplier=Element14; ComponentLink5URL: https://datasheet.ciiva.com/9117/aoa0000ce1-9117133.pdf?src-supplier=Avnet; ComponentLink5Description: https://datasheet.ciiva.com/9117/aoa0000ce1-9117133.pdf?src-supplier=Avnet; ComponentLink4URL: https://datasheet.ciiva.com/24068/aoa0000ce1-37422-24068951.pdf?src-supplier=Mouser; ComponentLink4Description: https://datasheet.ciiva.com/24068/aoa0000ce1-37422-24068951.pdf?src-supplier=Mouser; ComponentLink3URL: https://datasheet.ciiva.com/46374/1500035-46374086.pdf?src-supplier=Element14; ComponentLink3Description: https://datasheet.ciiva.com/46374/1500035-46374086.pdf?src-supplier=Element14; ComponentLink2URL: https://datasheet.ciiva.com/30235/4aoa0000ce1-30235766.pdf?src-supplier=Onlinecomponents.com; ComponentLink2Description: https://datasheet.ciiva.com/30235/4aoa0000ce1-30235766.pdf?src-supplier=Onlinecomponents.com; ComponentLink1URL: https://datasheet.ciiva.com/16765/70255313-16765782.pdf?src-supplier=Allied+Electronics; ComponentLink1Description: https://datasheet.ciiva.com/16765/70255313-16765782.pdf?src-supplier=Allied+Electronics; Component Kind: Standard; Case Code (Metric): 1608; Case Code (Imperial): 0603; Case/Package: 0603
R4 = CMP-2000-00874-1: Manufacturer Part Number: ERA3AEB103V; Manufacturer: Panasonic; Voltage Rating (DC): 75V; Temperature Coefficient: 25ppm/°C; Power Rating: 100mW; Width: 0.8mm; Voltage Rating: 75V; Termination: SMD/SMT; Supplier Part Number 1: P10KDBCT-ND; Supplier 1: Digi-Key; Stock 1: 912546; RoHS: Yes; REACH SVHC: No SVHC; Radiation Hardening: No; Pricing 1: 1=0.35000, 10=0.30000, 100=0.11720, 1000=0.04906, 2500=0.04498 (USD); Part Number: ERA-3AEB103V; Packaging: Tape and Reel; Package Quantity: 5000; Number of Terminations: 2; Number of Pins: 2; Mount: Surface Mount; Min Operating Temperature: -55°C; Military Standard: Not; Max Power Dissipation: 100mW; Max Operating Temperature: 155°C; Library Name: Altium Content Vault; Length: 1.6mm; Lead Free: Lead Free; Height: 0.45mm; Depth: 0.8mm; Contact Plating: Tin; Composition: Thin Film; ComponentLink13URL: https://datasheet.ciiva.com/5734/aoa0000ce26-5734527.pdf?src-supplier=Farnell; ComponentLink13Description: https://datasheet.ciiva.com/5734/aoa0000ce26-5734527.pdf?src-supplier=Farnell; ComponentLink12URL: https://datasheet.ciiva.com/46388/485442-46388110.pdf?src-supplier=Element14; ComponentLink12Description: https://datasheet.ciiva.com/46388/485442-46388110.pdf?src-supplier=Element14; ComponentLink11URL: https://datasheet.ciiva.com/30126/54aoa0000ce26-30126478.pdf?src-supplier=Onlinecomponents.com; ComponentLink11Description: https://datasheet.ciiva.com/30126/54aoa0000ce26-30126478.pdf?src-supplier=Onlinecomponents.com; ComponentLink10URL: https://datasheet.ciiva.com/46388/1776116-46388109.pdf?src-supplier=Element14; ComponentLink10Description: https://datasheet.ciiva.com/46388/1776116-46388109.pdf?src-supplier=Element14; ComponentLink9URL: https://datasheet.ciiva.com/24072/aoa0000ce26-197354-24072585.pdf?src-supplier=Mouser; ComponentLink9Description: https://datasheet.ciiva.com/24072/aoa0000ce26-197354-24072585.pdf?src-supplier=Mouser; ComponentLink8URL: https://datasheet.ciiva.com/16427/aoa0000ce26-16427097.pdf?src-supplier=Future+Electronics; ComponentLink8Description: https://datasheet.ciiva.com/16427/aoa0000ce26-16427097.pdf?src-supplier=Future+Electronics; ComponentLink7URL: https://datasheet.ciiva.com/46422/1776116-46422520.pdf?src-supplier=Element14; ComponentLink7Description: https://datasheet.ciiva.com/46422/1776116-46422520.pdf?src-supplier=Element14; ComponentLink6URL: https://datasheet.ciiva.com/17212/aoa0000ce26-17212070.pdf?src-supplier=Avnet+Europe; ComponentLink6Description: https://datasheet.ciiva.com/17212/aoa0000ce26-17212070.pdf?src-supplier=Avnet+Europe; ComponentLink5URL: https://datasheet.ciiva.com/46376/485442-46376357.pdf?src-supplier=Element14; ComponentLink5Description: https://datasheet.ciiva.com/46376/485442-46376357.pdf?src-supplier=Element14; ComponentLink4URL: https://datasheet.ciiva.com/9194/aoa0000ce26-9194213.pdf?src-supplier=Avnet; ComponentLink4Description: https://datasheet.ciiva.com/9194/aoa0000ce26-9194213.pdf?src-supplier=Avnet; ComponentLink3URL: https://datasheet.ciiva.com/29183/451250-da-01-en-widerstand-0603-era-29183809.pdf?src-supplier=Conrad; ComponentLink3Description: https://datasheet.ciiva.com/29183/451250-da-01-en-widerstand-0603-era-29183809.pdf?src-supplier=Conrad; ComponentLink2URL: https://datasheet.ciiva.com/11808/0900766b80fe20f7-11808028.pdf?src-supplier=RSComponents; ComponentLink2Description: https://datasheet.ciiva.com/11808/0900766b80fe20f7-11808028.pdf?src-supplier=RSComponents; ComponentLink1URL: https://datasheet.ciiva.com/5734/485442-5734528.pdf?src-supplier=Farnell; ComponentLink1Description: https://datasheet.ciiva.com/5734/485442-5734528.pdf?src-supplier=Farnell; Component Kind: Standard; Case Code (Metric): 1608; Case Code (Imperial): 0603; Case/Package: 0603
R5 = Res 0603: Resistor; Manufacturer Part Number: 3521390RFT; Manufacturer: TE Connectivity; Voltage Rating (DC): 250V; Temperature Coefficient: 100ppm/°C; Resistance: 390; Number of Resistors: 1; Voltage Rating: 250V; Termination: Solder; Supplier Part Number 1: A116022CT-ND; Supplier 1: Digi-Key; Stock 1: 13913; RoHS: Yes; REACH SVHC: No SVHC; Pricing 1: 1=0.71000, 10=0.52300, 100=0.30440, 1000=0.17071 (USD); Packaging: Tape and Reel; Number of Terminations: 2; Mount: Surface Mount; Min Operating Temperature: -55°C; Max Operating Temperature: 155°C; Library Name: SensorCard.SchLib; Length: 6.3mm; Height: 0.55mm; ELV: Compliant; Depth: 3.2mm; Composition: Thick Film; ComponentLink3URL: https://datasheet.ciiva.com/46413/1610601-46413100.pdf?src-supplier=Element14; ComponentLink3Description: https://datasheet.ciiva.com/46413/1610601-46413100.pdf?src-supplier=Element14; ComponentLink2URL: https://datasheet.ciiva.com/7823/1610601-7823212.pdf?src-supplier=Element14; ComponentLink2Description: https://datasheet.ciiva.com/7823/1610601-7823212.pdf?src-supplier=Element14; ComponentLink1URL: https://datasheet.ciiva.com/25610/0900766b81096b90-25610507.pdf?src-supplier=RSComponents; ComponentLink1Description: https://datasheet.ciiva.com/25610/0900766b81096b90-25610507.pdf?src-supplier=RSComponents; Component Kind: Standard; Case Code (Metric): 6432; Case Code (Imperial): 2512; Case/Package: 2512
R6 = CMP-2000-00133-1: Manufacturer Part Number: ERA-3AEB8451V; Manufacturer: Panasonic; Voltage Rating (DC): 75V; Temperature Coefficient: 25ppm/°C; Power Rating: 100mW; Width: 0.8mm; Voltage Rating: 75V; Supplier Part Number 1: P8.45KDBCT-ND; Supplier 1: Digi-Key; Stock 1: 22170; RoHS: Yes; REACH SVHC: No SVHC; Radiation Hardening: No; Pricing 1: 1=0.35000, 10=0.30000, 100=0.11720, 1000=0.04906, 2500=0.04498 (USD); Part Number: ERA3AEB8451V; Packaging: Tape and Reel; Package Quantity: 5000; Number of Terminations: 2; Number of Pins: 2; Mount: Surface Mount; Min Operating Temperature: -55°C; Military Standard: Not; Max Power Dissipation: 100mW; Max Operating Temperature: 155°C; Library Name: Altium Content Vault; Length: 1.6mm; Lead Free: Lead Free; Height: 0.45mm; Features: Automotive AEC-Q200; Depth: 0.8mm; Contact Plating: Tin; Composition: Metal Film; ComponentLink10URL: https://datasheet.ciiva.com/5971/485442-5971791.pdf?src-supplier=Farnell; ComponentLink10Description: https://datasheet.ciiva.com/5971/485442-5971791.pdf?src-supplier=Farnell; ComponentLink9URL: https://datasheet.ciiva.com/5971/485442-5971789.pdf?src-supplier=Farnell; ComponentLink9Description: https://datasheet.ciiva.com/5971/485442-5971789.pdf?src-supplier=Farnell; ComponentLink8URL: https://datasheet.ciiva.com/5971/485442-5971787.pdf?src-supplier=Farnell; ComponentLink8Description: https://datasheet.ciiva.com/5971/485442-5971787.pdf?src-supplier=Farnell; ComponentLink7URL: https://datasheet.ciiva.com/17212/aoa0000ce26-17212265.pdf?src-supplier=Avnet+Europe; ComponentLink7Description: https://datasheet.ciiva.com/17212/aoa0000ce26-17212265.pdf?src-supplier=Avnet+Europe; ComponentLink6URL: https://datasheet.ciiva.com/5971/485442-5971785.pdf?src-supplier=Farnell; ComponentLink6Description: https://datasheet.ciiva.com/5971/485442-5971785.pdf?src-supplier=Farnell; ComponentLink5URL: https://datasheet.ciiva.com/7829/485442-7829095.pdf?src-supplier=Element14; ComponentLink5Description: https://datasheet.ciiva.com/7829/485442-7829095.pdf?src-supplier=Element14; ComponentLink4URL: https://datasheet.ciiva.com/9194/aoa0000ce26-9194486.pdf?src-supplier=Avnet; ComponentLink4Description: https://datasheet.ciiva.com/9194/aoa0000ce26-9194486.pdf?src-supplier=Avnet; ComponentLink3URL: https://datasheet.ciiva.com/7829/485442-7829094.pdf?src-supplier=Element14; ComponentLink3Description: https://datasheet.ciiva.com/7829/485442-7829094.pdf?src-supplier=Element14; ComponentLink2URL: https://datasheet.ciiva.com/29183/452217-da-01-en-widerstand-0603-era-29183962.pdf?src-supplier=Conrad; ComponentLink2Description: https://datasheet.ciiva.com/29183/452217-da-01-en-widerstand-0603-era-29183962.pdf?src-supplier=Conrad; ComponentLink1URL: https://datasheet.ciiva.com/11814/0900766b80eb1d8c-11814904.pdf?src-supplier=RSComponents; ComponentLink1Description: https://datasheet.ciiva.com/11814/0900766b80eb1d8c-11814904.pdf?src-supplier=RSComponents; Component Kind: Standard; Case Code (Metric): 1608; Case Code (Imperial): 0603; Case/Package: 0603
R8 = CMP-2000-00068-1: Manufacturer Part Number: ERA-3AEB4641V; Manufacturer: Panasonic; Voltage Rating (DC): 75V; Temperature Coefficient: 25ppm/°C; Power Rating: 100mW; Width: 0.8mm; Voltage Rating: 75V; Termination: SMD/SMT; Supplier Part Number 1: P4.64KDBCT-ND; Supplier 1: Digi-Key; Stock 1: 47375; RoHS: Yes; REACH SVHC: No SVHC; Radiation Hardening: No; Pricing 1: 1=0.35000, 10=0.30000, 100=0.11730, 1000=0.04908, 2500=0.04499 (USD); Part Number: ERA3AEB4641V; Packaging: Tape and Reel; Package Quantity: 5000; Number of Terminations: 2; Number of Pins: 2; Mount: Surface Mount; Min Operating Temperature: -55°C; Military Standard: Not; Max Power Dissipation: 100mW; Max Operating Temperature: 155°C; Library Name: Altium Content Vault; Length: 1.6mm; Lead Free: Lead Free; Height: 0.45mm; Features: Automotive AEC-Q200; Depth: 0.8mm; Contact Plating: Tin; Composition: Metal Film; ComponentLink7URL: https://datasheet.ciiva.com/17213/aoa0000ce26-17213229.pdf?src-supplier=Avnet+Europe; ComponentLink7Description: https://datasheet.ciiva.com/17213/aoa0000ce26-17213229.pdf?src-supplier=Avnet+Europe; ComponentLink6URL: https://datasheet.ciiva.com/5971/485442-5971788.pdf?src-supplier=Farnell; ComponentLink6Description: https://datasheet.ciiva.com/5971/485442-5971788.pdf?src-supplier=Farnell; ComponentLink5URL: https://datasheet.ciiva.com/24062/aoa0000ce26-32660-24062713.pdf?src-supplier=Mouser; ComponentLink5Description: https://datasheet.ciiva.com/24062/aoa0000ce26-32660-24062713.pdf?src-supplier=Mouser; ComponentLink4URL: https://datasheet.ciiva.com/9195/aoa0000ce26-9195720.pdf?src-supplier=Avnet; ComponentLink4Description: https://datasheet.ciiva.com/9195/aoa0000ce26-9195720.pdf?src-supplier=Avnet; ComponentLink3URL: https://datasheet.ciiva.com/5971/485442-5971784.pdf?src-supplier=Farnell; ComponentLink3Description: https://datasheet.ciiva.com/5971/485442-5971784.pdf?src-supplier=Farnell; ComponentLink2URL: https://datasheet.ciiva.com/7763/485442-7763493.pdf?src-supplier=Element14; ComponentLink2Description: https://datasheet.ciiva.com/7763/485442-7763493.pdf?src-supplier=Element14; ComponentLink1URL: https://datasheet.ciiva.com/11810/0900766b80eb1d8c-11810059.pdf?src-supplier=RSComponents; ComponentLink1Description: https://datasheet.ciiva.com/11810/0900766b80eb1d8c-11810059.pdf?src-supplier=RSComponents; Component Kind: Standard; Case Code (Metric): 1608; Case Code (Imperial): 0603; Case/Package: 0603
R9 R10 = Res TH: Resistor through-hole; Manufacturer Part Number: WHE25KFET; Manufacturer: Ohmite; Tolerance: 1%; Temperature Coefficient: 20ppm/°C; Lead Diameter: 1mm; Weight: 0.080848oz; Termination: Axial; Supplier Part Number 1: WHE25KFETCT-ND; Supplier 1: Digi-Key; Stock 1: 718; RoHS: Yes; REACH SVHC: Unknown; Pricing 1: 1=1.88000, 10=1.66400, 25=1.54280, 50=1.45200, 100=1.27050, 250=1.08900 (USD); Packaging: Tape and Reel; Package Quantity: 500; Number of Terminations: 2; Min Operating Temperature: -55°C; Max Power Dissipation: 5W; Max Operating Temperature: 150°C; Library Name: SensorCard.SchLib; Length: 20mm; Diameter: 7.5mm; Composition: Wirewound; ComponentLink4URL: https://datasheet.ciiva.com/6495/res-wh-wn-6495262.pdf?src-supplier=Digi-Key; ComponentLink4Description: https://datasheet.ciiva.com/6495/res-wh-wn-6495262.pdf?src-supplier=Digi-Key; ComponentLink3URL: https://datasheet.ciiva.com/6495/res-wh-wn-6495260.pdf?src-supplier=Digi-Key; ComponentLink3Description: https://datasheet.ciiva.com/6495/res-wh-wn-6495260.pdf?src-supplier=Digi-Key; ComponentLink2URL: https://datasheet.ciiva.com/8080/whm-wnm-8080251.pdf?src-supplier=Element14; ComponentLink2Description: https://datasheet.ciiva.com/8080/whm-wnm-8080251.pdf?src-supplier=Element14; ComponentLink1URL: https://datasheet.ciiva.com/5635/res-wh-wn-5635609.pdf?src-supplier=Mouser; ComponentLink1Description: https://datasheet.ciiva.com/5635/res-wh-wn-5635609.pdf?src-supplier=Mouser; Component Kind: Standard; Case/Package: Axial
TP1-TP7 = CMP-2000-05575-1: Test Point, Yellow, 1-Pin THD, RoHS, Bulk; Manufacturer: Keystone; Plating: Silver; Outside Diameter: 2.54mm; Number of Ports: 1; Inside Diameter: 1.27mm; Body Material: PBT; Supplier Part Number 1: 36-5004-ND; Supplier 1: Digi-Key; Stock 1: 173022; RoHS: Yes; REACH SVHC: No SVHC; Pricing 1: 1=0.35000, 10=0.33500, 50=0.24380, 250=0.21032, 1000=0.17140, 2500=0.15296, 5000=0.14340 (USD); Packaging: Bulk; Package Reference: 5004; Package Quantity: 10; Package Description: 1-Pin Through Hole Device, Dia 2.54 mm; Orientation: Straight; Mounting Technology: ThroughHole; Mount: Through Hole; Material: Nylon; Manufacturer URL: http://www.keyelco.com/; Manufacturer Part Number: 5004; Library Name: Altium Content Vault; Length: 7.62mm; Lead Free: Lead Free; Housing Color: Yellow; Height: 0.3inch; Flammability Rating: UL94 V-0; Datasheet Version: Rev. G, 12/2008; Datasheet URL: http://www.keyelco.com/product-pdf.cfm?p=1313; Contact Plating: Silver; Contact Material: Bronze; Component Kind: Standard; Color: Yellow
U1 = ADS8860: IC ADC 16BIT 1MSPS 10VSSOP; Manufacturer: Texas Instruments; Supply Type: Analog; Signal to Noise Ratio (SNR): 93dB; Sampling Rate: 1MS/s; Resolution: 16b; Power Dissipation: 5.5mW; Polarity: Unipolar; Number of Inputs: 1; Number of Bits: 16; Nominal Supply Current: 1.8mA; Min Supply Voltage: 2.7V; Max Supply Voltage: 3.6V; Max Power Dissipation: 7.2mW; Interface: SPI; Integral Nonlinearity (INL): 2LSB; Supplier Part Number 1: 296-47183-ND; Supplier 1: Digi-Key; Stock 1: 558; RoHS: Yes; REACH SVHC: No SVHC; Pricing 1: 1=17.63000, 10=16.20200, 80=15.53075, 160=13.68388, 560=12.17275 (USD); Power Consumption: 5.5mW; Packaging: Rail/Tube; Number of Pins: 10; Number of Analog Inputs: 1; Number of A/D Converters: 1; Mount: Surface Mount; Min Operating Temperature: -40°C; Max Operating Temperature: 85°C; Library Name: SensorCard.SchLib; Lead Free: Lead Free; Contact Plating: Gold; ComponentLink6URL: http://www.ti.com/lit/gpn/ads8860?src-supplier=Mouser; ComponentLink6Description: http://www.ti.com/lit/gpn/ads8860?src-supplier=Mouser; ComponentLink5URL: http://www.farnell.com/datasheets/1883017.pdf?src-supplier=Element14; ComponentLink5Description: http://www.farnell.com/datasheets/1883017.pdf?src-supplier=Element14; ComponentLink4URL: http://www.ti.com/general/docs/lit/getliterature.tsp?genericPartNumber=ADS8860&&fileType=pdf&src-supplier=Avnet; ComponentLink4Description: http://www.ti.com/general/docs/lit/getliterature.tsp?genericPartNumber=ADS8860&&fileType=pdf&src-supplier=Avnet; ComponentLink3URL: http://www.ti.com/lit/ds/symlink/ads8860.pdf?src-supplier=Digi-Key; ComponentLink3Description: http://www.ti.com/lit/ds/symlink/ads8860.pdf?src-supplier=Digi-Key; ComponentLink2URL: http://www.ti.com/general/docs/lit/getliterature.tsp?genericPartNumber=ADS8860&amp;&amp;fileType=pdf&src-supplier=Farnell; ComponentLink2Description: http://www.ti.com/general/docs/lit/getliterature.tsp?genericPartNumber=ADS8860&amp;&amp;fileType=pdf&src-supplier=Farnell; ComponentLink1URL: http://www.ti.com/lit/pdf/slyb174?src-supplier=Digi-Key; ComponentLink1Description: http://www.ti.com/lit/pdf/slyb174?src-supplier=Digi-Key; Component Kind: Standard; Case/Package: VSSOP
U2 = CMP-0272-00853-3: Precision, 20 MHz, 0.9 pA Ib, RRIO, CMOS Operational Amplifier, 1.8 to 5.5 V, -40 to 125 degC, 5-pin SOT23 (DBV5), Green (RoHS & no Sb/Br); Manufacturer: Texas Instruments; Voltage Gain: 132dB; Vn at 1kHz(Typ)(nV/rtHz): 8.5; Vio (25C)(Max)(mV): 0.15; Unity Gain Bandwidth Product: 20MHz; Total Supply Voltage(Min)(+5V is 5, +/-5V is 10): 1.8; Total Supply Voltage(Max)(+5V is 5, +/-5V is 10): 5.5; Sub Family: High Output Current Amplifier (>=50mA), Low Input Bias Current Amp (<=10pA), Low Noise Amp (<=10nV/rtHz), Low Offset Voltage Amplifier (<=500uV), Low Supply Voltage Amplifier (<=2.7V), Precision Amplifier; Slew Rate(Typ)(V/us): 10; Power Supply Rejection Ratio (PSRR): 106.02dB; Output Current per Channel: 65mA; Operating Supply Voltage: 2.75V; Operating Supply Current: 1.5mA; Offset Drift(Typ)(uV/C): 1.5; Number of Elements: 1; Number of Channels: 2; Nominal Supply Current: 1.45mA; Min Supply Voltage: 1.8V; Min Dual Supply Voltage: 900mV; Max Supply Voltage: 5.5V; Max I/O Voltage: 150uV; Iq per channel(Max)(mA): 1.75; Input Bias Current: 0.9pA; IIB(Max)(pA): 0.9; Common Mode Rejection Ratio: 100dB; CMRR(Min)(dB): 100; Supplier Part Number 1: 296-29480-1-ND; Supplier 1: Digi-Key; Stock 1: 26460; REACH SVHC: No SVHC; Radiation Hardening: No; Pricing 1: 1=2.14000, 10=1.92200, 100=1.54450, 500=1.26896, 1000=1.05142 (USD); PartNumber: OPA320AIDBVR; Packaging: Tape and Reel; PackageVersion: 4073253-4/K, 03/2006; PackageReference: DBV0005A; Package Quantity: 3000; PackageDescription: 5-Pin Plastic Small Outline, Body 1.6 x 2.9 mm, Pitch 0.95 mm; Output Current: 65mA; Number of Pins: 5; Number of Circuits: 1; Number of Amplifiers: 1; Mounting Technology: Surface Mount; Min Operating Temperature: -40°C; Max Operating Temperature: 125°C; Max Dual Supply Voltage: 2.75V; Manufacturer Part Number: OPA320AIDBVR; Library Name: Altium Content Vault; Lead Free: Lead Free; Input Offset Voltage (Vos): 150uV; Gain Bandwidth Product: 20MHz; Eco-Plan: Green (RoHS & no Sb/Br); DatasheetVersion: SBOS513D; Contact Plating: Gold; ComponentLink6URL: https://datasheet.ciiva.com/1799/opa320-1799045.pdf?src-supplier=Digi-Key; ComponentLink6Description: https://datasheet.ciiva.com/1799/opa320-1799045.pdf?src-supplier=Digi-Key; ComponentLink5URL: https://datasheet.ciiva.com/1799/opa320-1799044.pdf?src-supplier=Digi-Key; ComponentLink5Description: https://datasheet.ciiva.com/1799/opa320-1799044.pdf?src-supplier=Digi-Key; ComponentLink4URL: https://datasheet.ciiva.com/1799/opa320-1799043.pdf?src-supplier=Digi-Key; ComponentLink4Description: https://datasheet.ciiva.com/1799/opa320-1799043.pdf?src-supplier=Digi-Key; ComponentLink3URL: http://www.ti.com/litv/pdf/mpds018i; ComponentLink3Description: Package Specification; ComponentLink2URL: http://www.ti.com/general/docs/lit/getliterature.tsp?genericPartNumber=OPA320&fileType=pdf; ComponentLink2Description: Datasheet; ComponentLink1URL: http://www.ti.com/; ComponentLink1Description: Manufacturer URL; Component Kind: Standard; Code_JEDEC: MO-178; Case/Package: SOT-23-5; Bandwidth: 20MHz
U3 = CMP-0367-00254-2: Low Noise, Very Low Drift, Precision Voltage Reference, -40 to 125 degC, 8-pin VSSOP (DGK), Green (RoHS & no Sb/Br); Manufacturer: Texas Instruments; VO(V): 5; VI(Min)(V): 5.2; VI(Max)(V): 18; Tolerance: 0.05%; Temperature Coefficient: 3ppm/°C; Temp Coeff(Max)(ppm/ degree C): 3; SubFamily: Series Voltage Reference; Reference Voltage: 5V; Reference Type: Series; Rating: Catalog; Quiescent Current: 1mA; Output Current: 10mA; Number of Channels: 1; Nominal Supply Current: 800uA; Min Input Voltage: 5.2V; Max Input Voltage: 18V; Iq(Max)(uA): 1000; Iout/Iz(Max)(mA): 10; Initial Accuracy @ 25/deg C(%): 0.05; Width: 3.1mm; Weight: 0.00067oz; Supplier Part Number 1: 296-24507-1-ND; Supplier 1: Digi-Key; Stock 1: 5147; RoHS: Yes; REACH SVHC: No SVHC; Radiation Hardening: No; Pricing 1: 1=6.80000, 10=6.14500, 100=5.08770 (USD); PartNumber: REF5050IDGKT; Packaging: Tape and Reel; PackageVersion: 4073329/E; PackageReference: DGK0008A; Package Quantity: 1; PackageDescription: 8-Pin Thin Small Outline Package 3 x 3 mm Body ,0.65 mm Pitch; Output Voltage: 5V; Number of Pins: 8; Mounting Technology: Surface Mount; Min Operating Temperature: -40°C; Max Output Voltage: 5V; Max Output Current: 10mA; Max Operating Temperature: 125°C; Manufacturer Part Number: REF5050IDGKT; Library Name: Altium Content Vault; Length: 3.1mm; Lead Free: Lead Free; Height: 0.95mm; Eco-Plan: Green (RoHS & no Sb/Br); DatasheetVersion: SBOS410E; ComponentLink9URL: https://datasheet.ciiva.com/14197/ref5050-14197087.pdf?src-supplier=Avnet; ComponentLink9Description: https://datasheet.ciiva.com/14197/ref5050-14197087.pdf?src-supplier=Avnet; ComponentLink8URL: https://datasheet.ciiva.com/2007/ref5020-2007554.pdf?src-supplier=Digi-Key; ComponentLink8Description: https://datasheet.ciiva.com/2007/ref5020-2007554.pdf?src-supplier=Digi-Key; ComponentLink7URL: https://datasheet.ciiva.com/2007/ref5020-2007553.pdf?src-supplier=Digi-Key; ComponentLink7Description: https://datasheet.ciiva.com/2007/ref5020-2007553.pdf?src-supplier=Digi-Key; ComponentLink6URL: https://datasheet.ciiva.com/22666/1885920-22666106.pdf?src-supplier=Element14; ComponentLink6Description: https://datasheet.ciiva.com/22666/1885920-22666106.pdf?src-supplier=Element14; ComponentLink5URL: https://datasheet.ciiva.com/26180/ref5050-26180982.pdf?src-supplier=Avnet+Europe; ComponentLink5Description: https://datasheet.ciiva.com/26180/ref5050-26180982.pdf?src-supplier=Avnet+Europe; ComponentLink4URL: https://datasheet.ciiva.com/2007/ref5020-2007552.pdf?src-supplier=Digi-Key; ComponentLink4Description: https://datasheet.ciiva.com/2007/ref5020-2007552.pdf?src-supplier=Digi-Key; ComponentLink3URL: http://www.ti.com/litv/pdf/mpds028d; ComponentLink3Description: Package Specification; ComponentLink2URL: http://www.ti.com/general/docs/lit/getliterature.tsp?genericPartNumber=REF5050&fileType=pdf; ComponentLink2Description: Datasheet; ComponentLink1URL: http://www.ti.com/; ComponentLink1Description: Manufacturer URL; Component Kind: Standard; Code_JEDEC: MO-187; Case/Package: VSSOP
